# Altium schematic sheet Relay Board.SchDoc
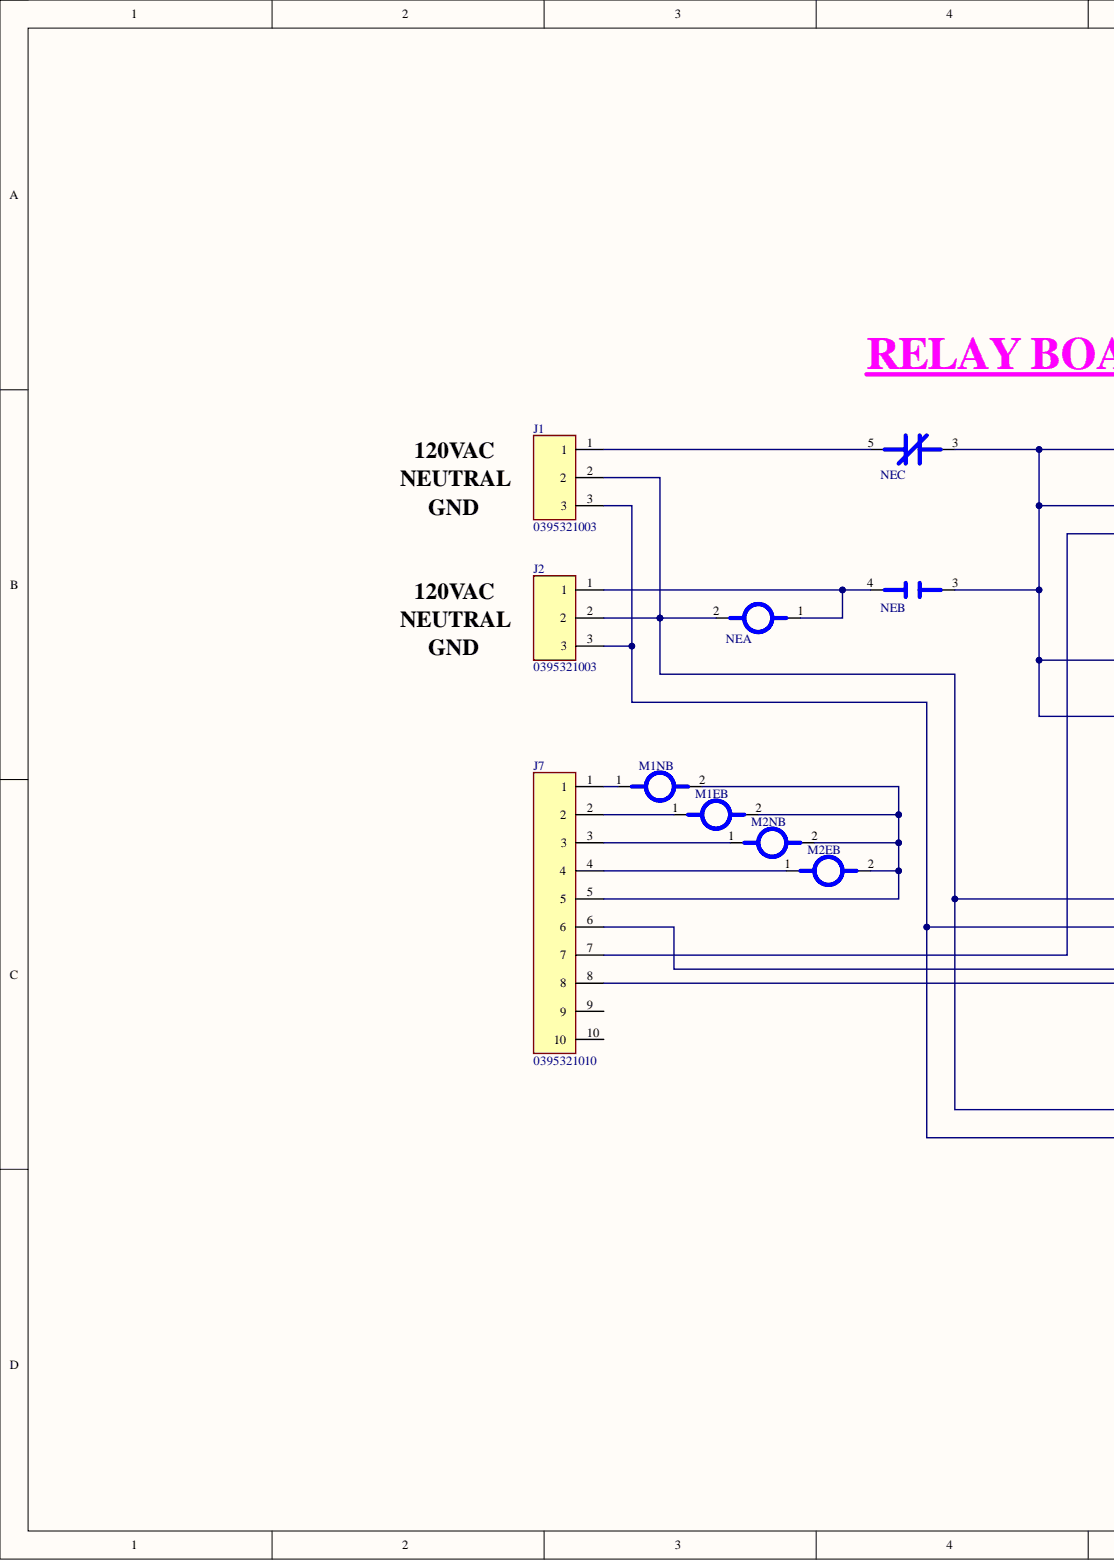
[diagram p0: Relay Board.SchDoc, left]
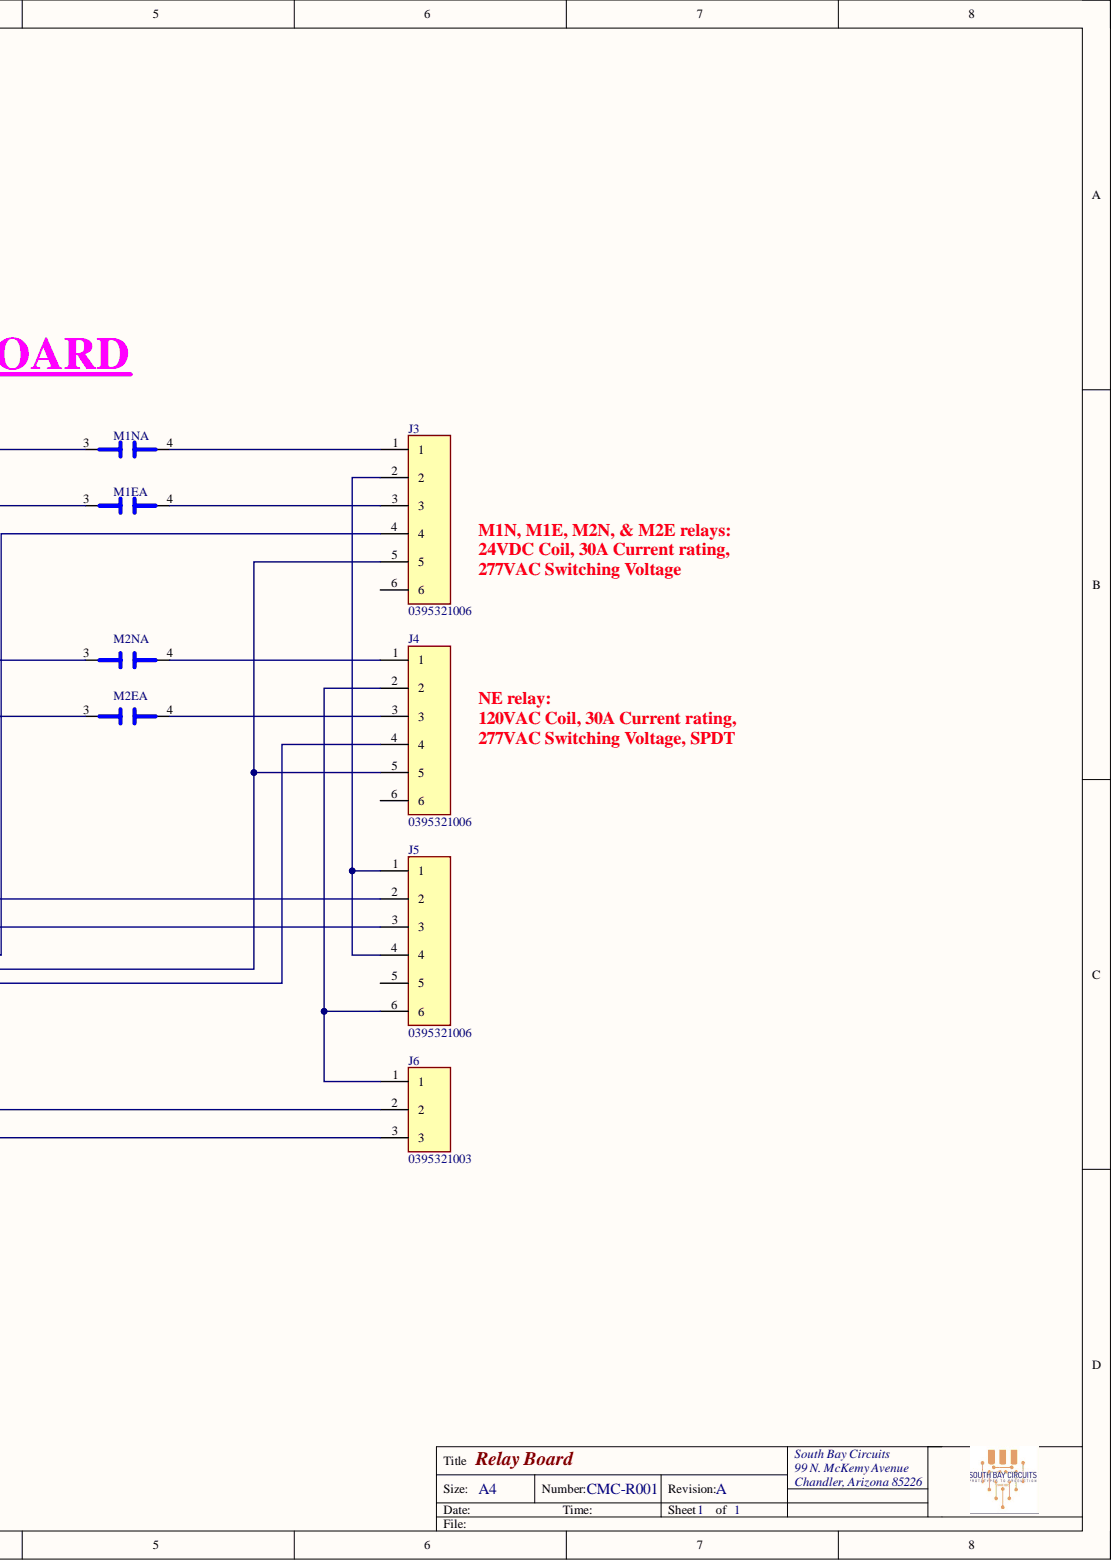
[diagram p1: Relay Board.SchDoc, right]

## Parts
J1  0395321003  [HDR1X3_MOLEX_TERMINAL]
J2  0395321003  [HDR1X3_MOLEX_TERMINAL]
J3  0395321006  [HDR1X6_MOLEX_TERMINAL]
J4  0395321006  [HDR1X6_MOLEX_TERMINAL]
J5  0395321006  [HDR1X6_MOLEX_TERMINAL]
J6  0395321003  [HDR1X3_MOLEX_TERMINAL]
J7  0395321010  [HDR1X10_MOLEX_TERMINAL]
M1E  G6QE-1A DC24  [RELAY_G6QE-1A_OMR]  (value not drawn)  (parts A,B)
M1N  G6QE-1A DC24  [RELAY_G6QE-1A_OMR]  (value not drawn)  (parts A,B)
M2E  G6QE-1A DC24  [RELAY_G6QE-1A_OMR]  (value not drawn)  (parts A,B)
M2N  G6QE-1A DC24  [RELAY_G6QE-1A_OMR]  (value not drawn)  (parts A,B)
NE  J115F21C120VACS  [RELAY_J115F2]  (value not drawn)  (parts A,B,C)

## Nets
NetJ1_1: J1.1 NE.5
NetJ1_2: J1.2 J2.2 J5.2 J6.2 NE.2
NetJ1_3: J1.3 J2.3 J5.3 J6.3
NetJ2_1: J2.1 NE.1 NE.4
NetJ3_1: J3.1 M1N.4
NetJ3_2: J3.2 J5.1 J5.4
NetJ3_3: J3.3 M1E.4
NetJ3_4: J3.4 J7.7
NetJ3_5: J3.5 J4.5 J7.6
NetJ4_1: J4.1 M2N.4
NetJ4_2: J4.2 J5.6 J6.1
NetJ4_3: J4.3 M2E.4
NetJ4_4: J4.4 J7.8
NetJ7_1: J7.1 M1N.1
NetJ7_2: J7.2 M1E.1
NetJ7_3: J7.3 M2N.1
NetJ7_4: J7.4 M2E.1
NetJ7_5: J7.5 M1E.2 M1N.2 M2E.2 M2N.2
NetM1E_3: M1E.3 M1N.3 M2E.3 M2N.3 NE.3

Not connected: J3 6; J4 6; J5 5; J7 9 10

## Library data of the parts
J1 J2 J6 = MOLEX_0395321003: TERM BLOCK HDR 3POS 90DEG 5.08MM; Manufacturer: Molex; Component Kind: Standard; Library Name: Relay Board.SCHLIB; Manufacturer Part Number: 0395321003; Package: None; Supplier 1: Digi-Key; Supplier Part Number 1: WM7846-ND; Value: 0395321003
J3-J5 = MOLEX_0395321006: TERM BLOCK HDR 6POS 90DEG 5.08MM; Manufacturer: Molex; Component Kind: Standard; Library Name: Relay Board.SCHLIB; Manufacturer Part Number: 0395321006; Package: None; Supplier 1: Digi-Key; Supplier Part Number 1: 900-0395321006-ND; Value: 0395321006
J7 = MOLEX_0395321010: TERM BLOCK HDR 10POS 5.08MM; Manufacturer: Molex; Component Kind: Standard; Library Name: Relay Board.SCHLIB; Manufacturer Part Number: 0395321010; Package: None; Supplier 1: Digi-Key; Supplier Part Number 1: WM7851-ND; Value: 0395321010
M1E M1N M2E M2N = G6QE-1A DC24: RELAY PWR PCB SPST-NO 32A 24VDC; Manufacturer: Omron; Component Kind: Standard; Library Name: Relay Board.SCHLIB; Manufacturer Part Number: G6QE-1A DC24; Supplier 1: Mouser; Supplier Part Number 1: 653-G6QE-1ADC24; Type: DEV; Copyright: Copyright (C) 2022 Ultra Librarian. All rights reserved.
NE = J115F21C120VACS: RELAY UL & TUV GEN PURP SPDT 40A; Manufacturer: CIT Relay and Switch; Component Kind: Standard; Library Name: Relay Board.SCHLIB; Manufacturer Part Number: J115F21C120VACS; Supplier 1: Digi-Key; Supplier Part Number 1: 2449-J115F21C120VACS-ND; Type: DEV
